# Altium schematic sheet LH-Cloud-STM32F407IGT6-V1.0.SchDoc(LH-Cloud-STM32F407IGT6-V1.0)
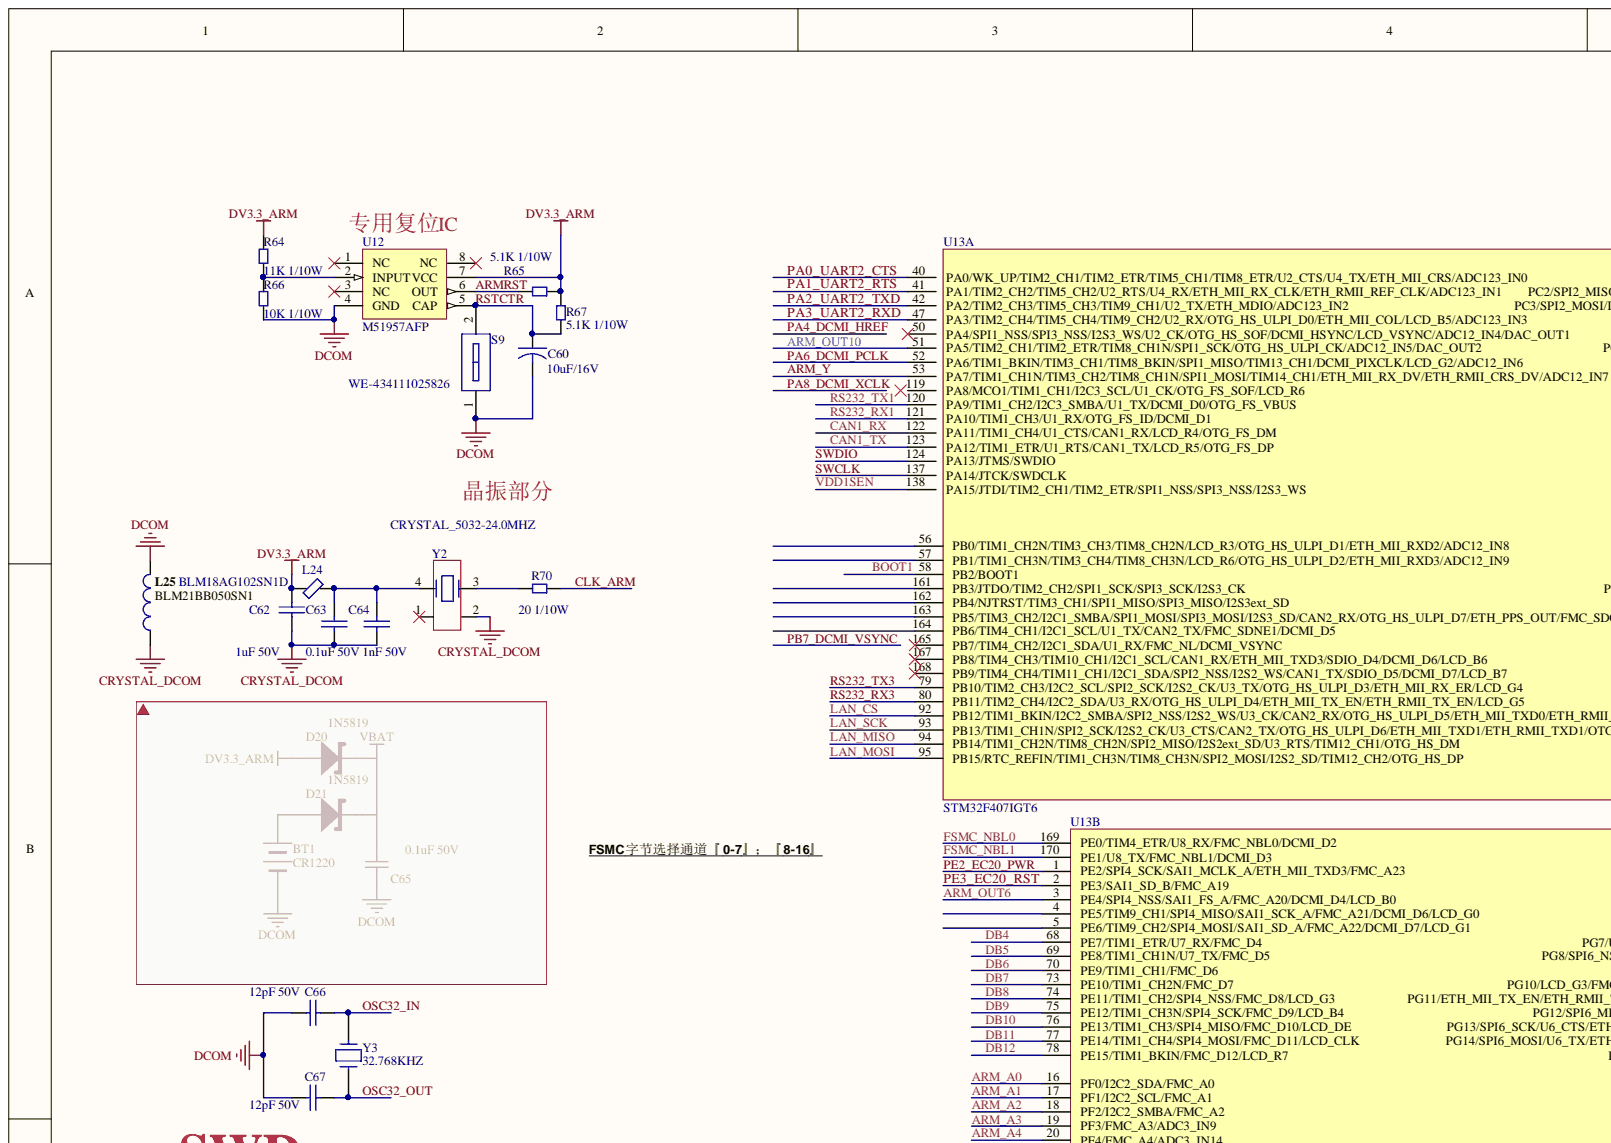
[diagram p0: LH-Cloud-STM32F407IGT6-V1.0.SchDoc, top left]
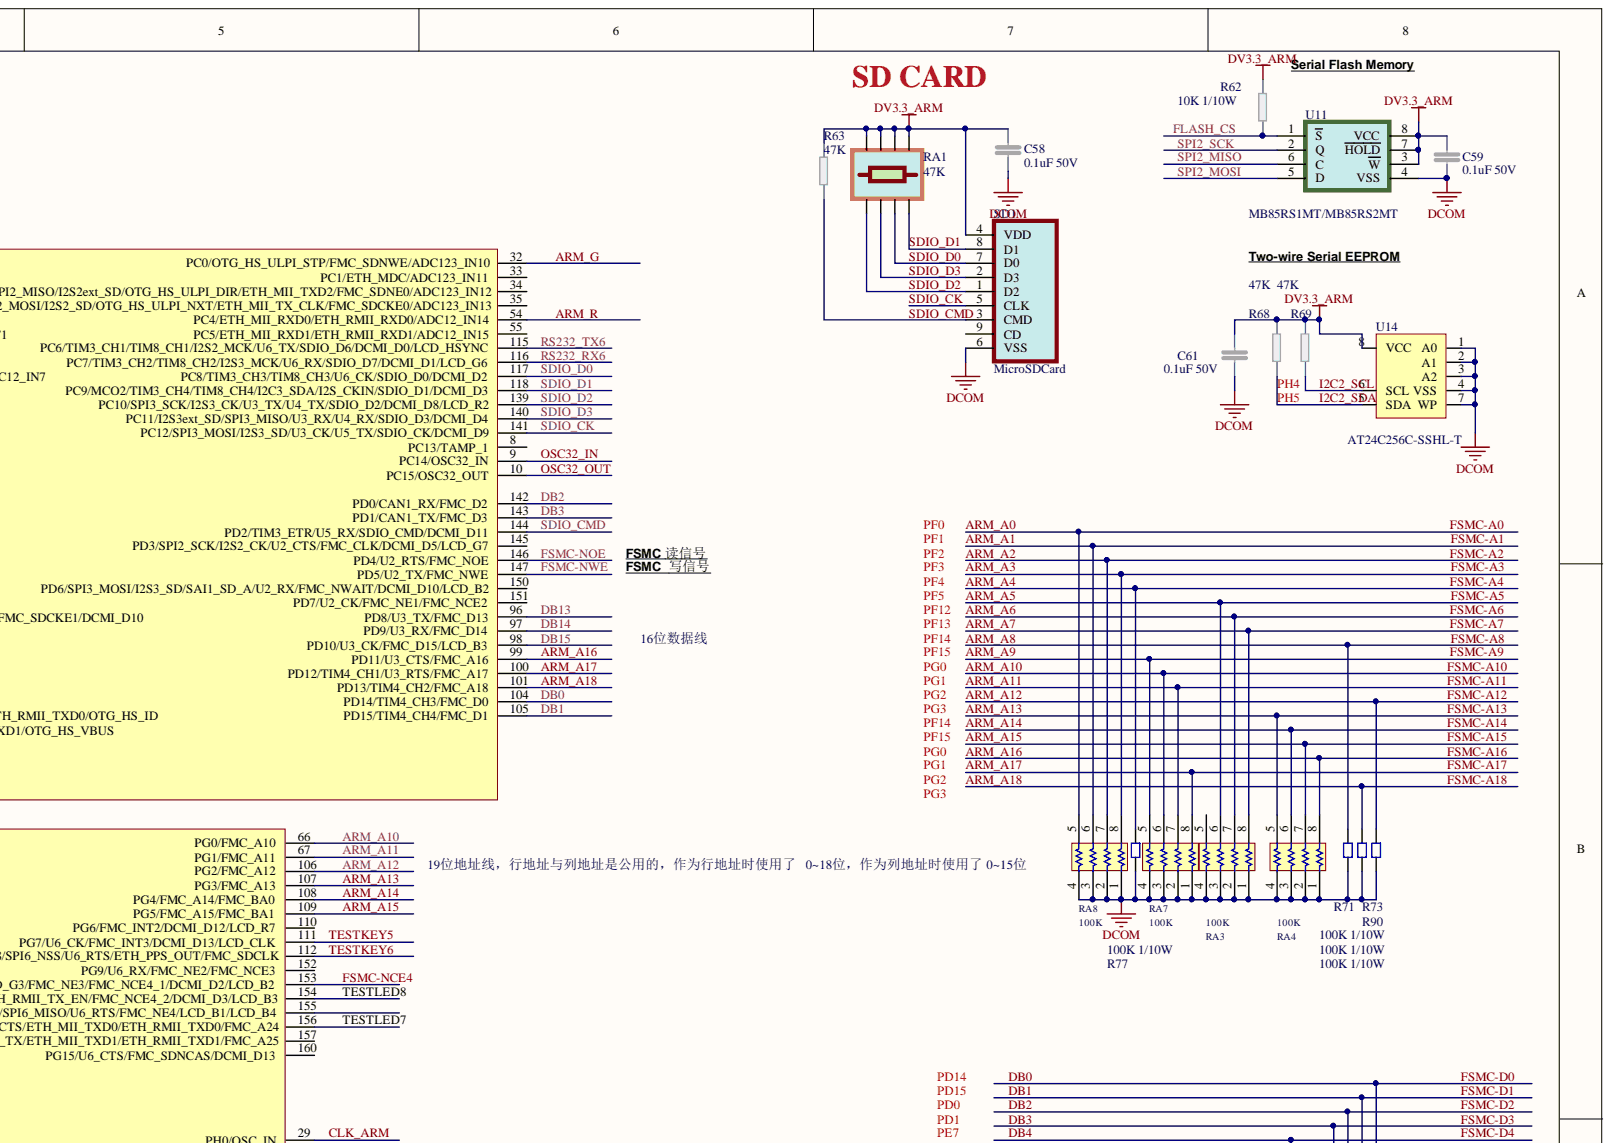
[diagram p1: LH-Cloud-STM32F407IGT6-V1.0.SchDoc, top right]
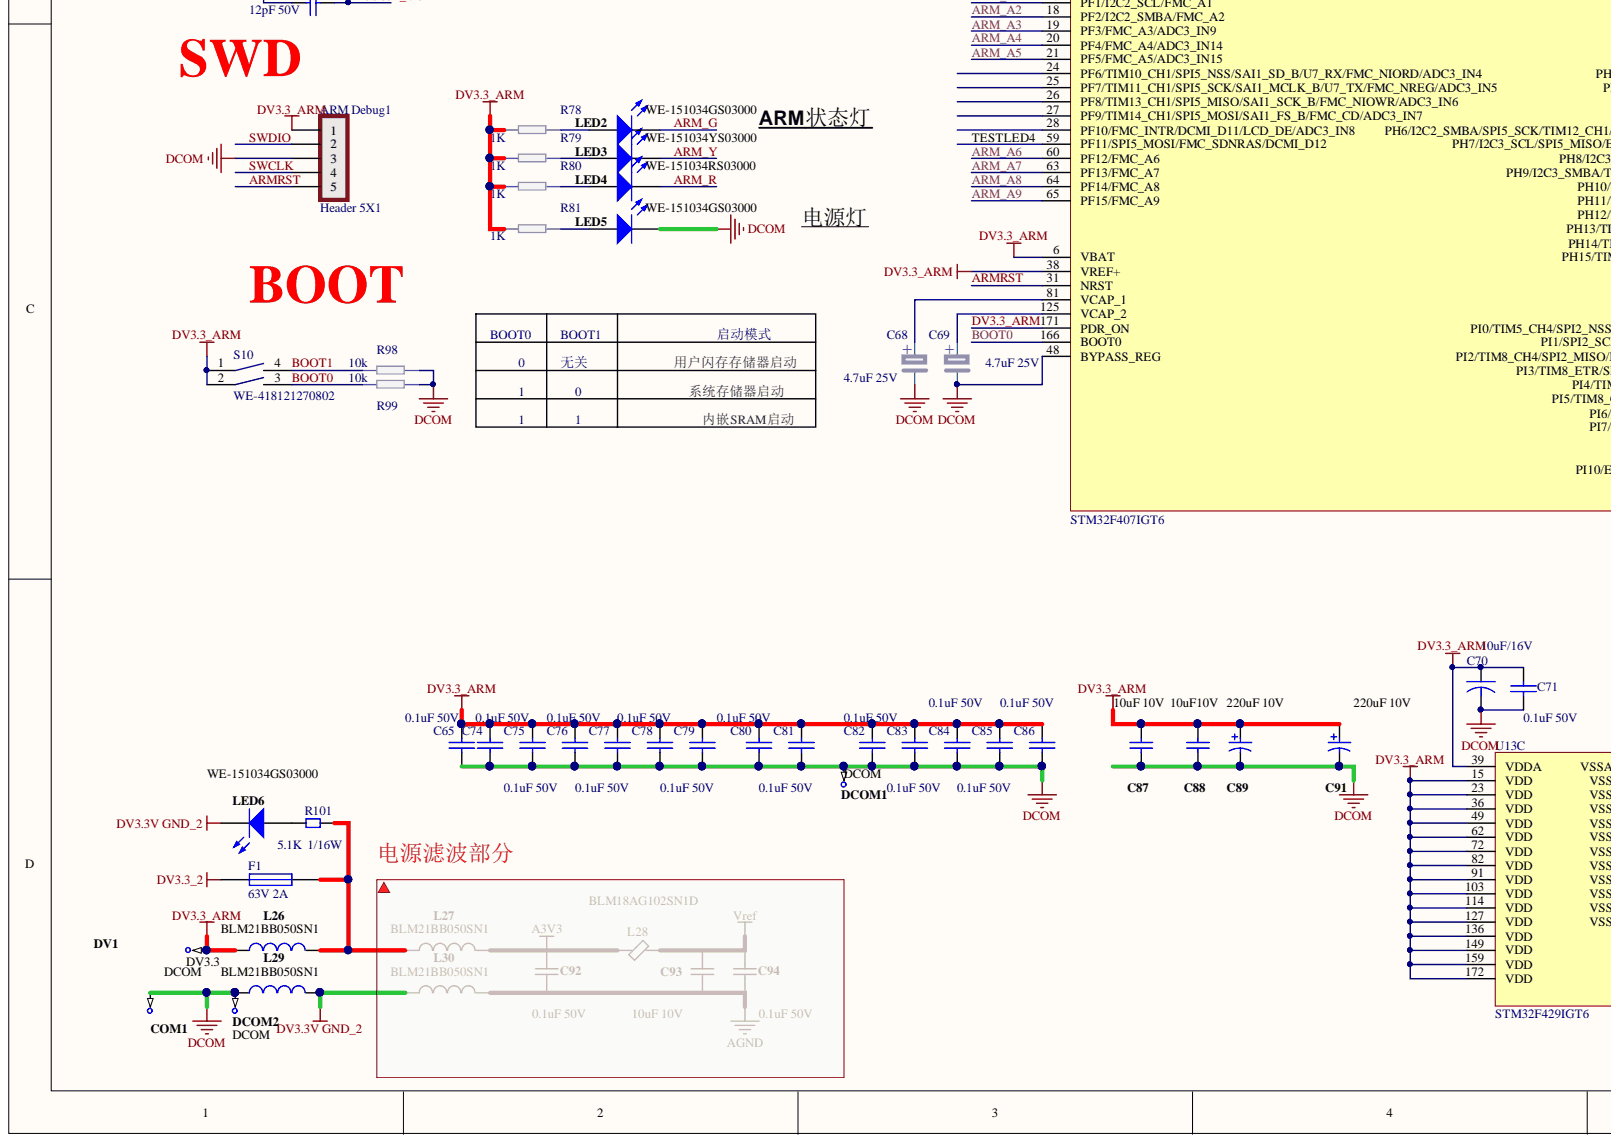
[diagram p2: LH-Cloud-STM32F407IGT6-V1.0.SchDoc, bottom left]
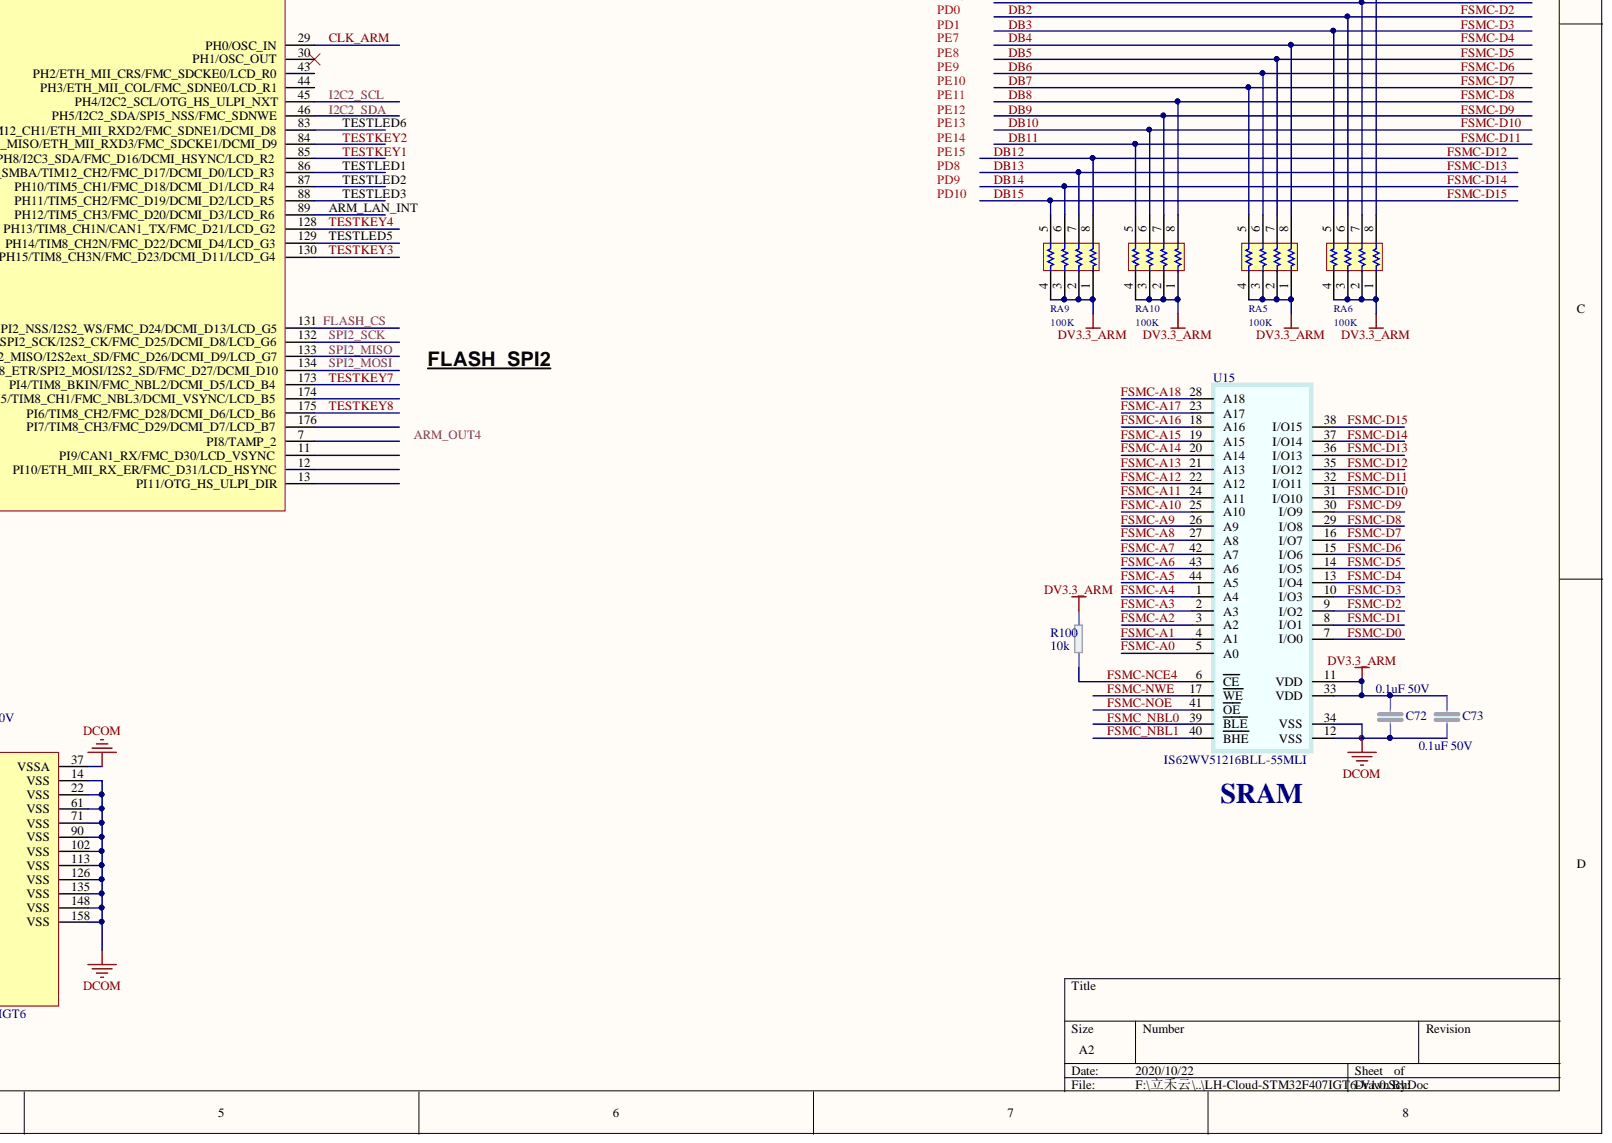
[diagram p3: LH-Cloud-STM32F407IGT6-V1.0.SchDoc, bottom right]

## Parts
ARM_Debug1  Header 5X1  [WR-WTB-2.5mm-5pin_68800511622]
C58  0.1uF 50V  [C0603]
C59  0.1uF 50V  [C0603]
C60  10uF/16V  [CAPBCASE]
C61  0.1uF 50V  [0603P]
C62  1uF 50V  [C0603_105/50V]
C63  0.1uF 50V  [C0603]
C64  1nF 50V  [C0603_102/50V]
C65  0.1uF 50V  value 0.1uF  [C0603]
C66  12pF 50V  [C0603_120/50V]
C67  12pF 50V  [C0603_120/50V]
C68  4.7uF 25V  [TAN-A]
C69  4.7uF 25V  [TAN-A]
C70  10uF/16V  [CAPBCASE_106/16V]
C71  0.1uF 50V  [C0603]
C72  0.1uF 50V  [C0603_104/50V]
C73  0.1uF 50V  [C0603_104/50V]
C74  0.1uF 50V  value 0.1uF  [C0603]
C75  0.1uF 50V  value 0.1uF  [C0603]
C76  0.1uF 50V  value 0.1uF  [C0603]
C77  0.1uF 50V  value 0.1uF  [C0603]
C78  0.1uF 50V  value 0.1uF  [C0603]
C79  0.1uF 50V  value 0.1uF  [C0603]
C80  0.1uF 50V  value 0.1uF  [C0603]
C81  0.1uF 50V  value 0.1uF  [C0603]
C82  0.1uF 50V  value 0.1uF  [C0603]
C83  0.1uF 50V  value 0.1uF  [C0603]
C84  0.1uF 50V  value 0.1uF  [C0603]
C85  0.1uF 50V  value 0.1uF  [C0603]
C86  0.1uF 50V  value 0.1uF  [C0603]
C87  10uF 10V  [C0805_106/50V]
C88  10uF10V  [C0805_106/50V]
C89  220uF 10V  [CAPDCASE_E]
C91  220uF 10V  [CAPDCASE_E]
COM1  DCOM  [TestPin]  (no BOM)
DCOM1  DCOM  [TestPin]  (no BOM)
DCOM2  DCOM  [TestPin]  (no BOM)
DV1  DV3.3  [TestPin]  (no BOM)
F1  63V 2A  [F1206_1A/105V]
L24  BLM18AG102SN1D  [0603_BLM18AG102SN1D]
L25  BLM21BB050SN1  [0805_BLM21BB050SN1]
L26  BLM21BB050SN1  [0805_BLM21BB050SN1]
L29  BLM21BB050SN1  [0805_BLM21BB050SN1]
LED2  WE-151034GS03000  [LED_3MM]
LED3  WE-151034YS03000  [LED_3MM]
LED4  WE-151034RS03000  [LED_3MM]
LED5  WE-151034GS03000  [LED_3MM]
LED6  WE-151034GS03000  [LED_3MM]
R62  10K 1/10W  [res0603_103]
R63  47K  [RES0603_473]
R64  11K 1/10W  [res0603_113]
R65  5.1K 1/10W  [res0603_512]
R66  10K 1/10W  [res0603_103]
R67  5.1K 1/10W  [res0603_512]
R68  47K  [RES0603_473]
R69  47K  [RES0603_473]
R70  20 1/10W  [res0603_200]
R71  100K 1/10W  [RES0603_100]
R73  100K 1/10W  [RES0603_100]
R77  100K 1/10W  [RES0603_100]
R78  1K  [RES0603_102]
R79  1K  [RES0603_102]
R80  1K  [RES0603_102]
R81  1K  [RES0603_102]
R90  100K 1/10W  [RES0603_100]
R98  10k  [RES0603_104]
R99  10k  [RES0603_104]
R100  10k  [res0603_103]
R101  5.1K  1/16W  [res0603_512]
RA1  47K  [ra_yc164_102]
RA3  100K  [ra_yc164_104]
RA4  100K  [ra_yc164_104]
RA5  100K  [ra_yc164_104]
RA6  100K  [ra_yc164_104]
RA7  100K  [ra_yc164_104]
RA8  100K  [ra_yc164_104]
RA9  100K  [ra_yc164_104]
RA10  100K  [ra_yc164_104]
S9  WE-434111025826  [KEY735SO]
S10  WE-418121270802  [WS-DISV-2.54MM-2PIN_418121270802]
SD1  MicroSDCard  [microsdcard]
U11  MB85RS1MT/MB85RS2MT  [MB85RS2MT_SOP8W]
U12  M51957AFP  [SO8]
U13  STM32F407IGT6  [LQFP-176_STM32F407IGT6-176]  (parts A,B,C)
U14  AT24C256C-SSHL-T  [SOIC8_AT24C08]
U15  IS62WV51216BLL-55MLI  [IS62WV51216BLL_TSOP44]
Y2  CRYSTAL_5032-24.0MHZ  [CR-5032_24MHZ]
Y3  32.768KHZ  [CR_3215_32768]

## Nets
CRYSTAL_DCOM: C62.2 C63.2 C64.2 L25.1 Y2.2
DCOM: ARM_Debug1.3 C58.1 C59.2 C60.2 C61.2 C65.2 C66.2 C67.2 C68.2 C69.2 C70.2 C71.1 C72.2 C73.2 C74.2 C75.2 C76.2 C77.2 C78.2 C79.2 C80.2 C81.2 C82.2 C83.2 C84.2 C85.2 C86.2 C87.2 C88.2 C89.2 C91.2 COM1.1 DCOM1.1 DCOM2.1 L25.2 L29.1 LED5.2 R66.1 R71.2 R73.2 R77.2 R90.2 R98.2 R99.2 RA3.1 RA3.2 RA3.3 RA3.4 RA4.1 RA4.2 RA4.3 RA4.4 RA7.1 RA7.2 RA7.3 RA7.4 RA8.1 RA8.2 RA8.3 RA8.4 S9.1 SD1.6(VSS) U11.4(VSS) U12.4(GND) U13.14(VSS) U13.22(VSS) U13.37(VSSA) U13.48(BYPASS_REG) U13.61(VSS) U13.71(VSS) U13.90(VSS) U13.102(VSS) U13.113(VSS) U13.126(VSS) U13.135(VSS) U13.148(VSS) U13.158(VSS) U14.1(A0) U14.2(A1) U14.3(A2) U14.4(VSS) U14.7(WP) U15.12(VSS) U15.34(VSS)
DV3.3_2: F1.2
DV3.3V GND_2: L29.2 LED6.2
ARM_A0: RA8.5 U13.16(PF0/I2C2_SDA/FMC_A0) U15.5(A0)
ARM_A1: RA8.6 U13.17(PF1/I2C2_SCL/FMC_A1) U15.4(A1)
ARM_A2: RA8.7 U13.18(PF2/I2C2_SMBA/FMC_A2) U15.3(A2)
ARM_A3: RA8.8 U13.19(PF3/FMC_A3/ADC3_IN9) U15.2(A3)
ARM_A4: R77.1 U13.20(PF4/FMC_A4/ADC3_IN14) U15.1(A4)
ARM_A5: RA3.6 U13.21(PF5/FMC_A5/ADC3_IN15) U15.44(A5)
ARM_A6: RA3.7 U13.60(PF12/FMC_A6) U15.43(A6)
ARM_A7: RA3.8 U13.63(PF13/FMC_A7) U15.42(A7)
ARM_A8: R71.1 U13.64(PF14/FMC_A8) U15.27(A8)
ARM_A9: RA7.5 U13.65(PF15/FMC_A9) U15.26(A9)
ARM_A10: RA7.6 U13.66(PG0/FMC_A10) U15.25(A10)
ARM_A11: RA7.7 U13.67(PG1/FMC_A11) U15.24(A11)
ARM_A12: R90.1 U13.106(PG2/FMC_A12) U15.22(A12)
ARM_A13: RA4.5 U13.107(PG3/FMC_A13) U15.21(A13)
ARM_A14: RA4.6 U13.108(PG4/FMC_A14/FMC_BA0) U15.20(A14)
ARM_A15: RA4.7 U13.109(PG5/FMC_A15/FMC_BA1) U15.19(A15)
ARM_A16: RA4.8 U13.99(PD11/U3_CTS/FMC_A16) U15.18(A16)
ARM_A17: RA7.8 U13.100(PD12/TIM4_CH1/U3_RTS/FMC_A17) U15.23(A17)
ARM_A18: R73.1 U13.101(PD13/TIM4_CH2/FMC_A18) U15.28(A18)
ARM_G: LED2.2 U13.32(PC0/OTG_HS_ULPI_STP/FMC_SDNWE/ADC123_IN10)
ARM_LAN_INT: U13.89(PH12/TIM5_CH3/FMC_D20/DCMI_D3/LCD_R6)
ARM_OUT6: U13.3(PE4/SPI4_NSS/SAI1_FS_A/FMC_A20/DCMI_D4/LCD_B0)
ARM_OUT10: U13.51(PA5/TIM2_CH1/TIM2_ETR/TIM8_CH1N/SPI1_SCK/OTG_HS_ULPI_CK/ADC12_IN5/DAC_OUT2)
ARM_R: LED4.2 U13.54(PC4/ETH_MII_RXD0/ETH_RMII_RXD0/ADC12_IN14)
ARM_Y: LED3.2 U13.53(PA7/TIM1_CH1N/TIM3_CH2/TIM8_CH1N/SPI1_MOSI/TIM14_CH1/ETH_MII_RX_DV/ETH_RMII_CRS_DV/ADC12_IN7)
ARMRST: ARM_Debug1.5 R65.2 U12.6(OUT) U13.31(NRST)
BOOT0: R99.1 S10.3 U13.166(BOOT0)
BOOT1: R98.1 S10.4 U13.58(PB2/BOOT1)
CAN1_RX: U13.122(PA11/TIM1_CH4/U1_CTS/CAN1_RX/LCD_R4/OTG_FS_DM)
CAN1_TX: U13.123(PA12/TIM1_ETR/U1_RTS/CAN1_TX/LCD_R5/OTG_FS_DP)
CLK_ARM: R70.1 U13.29(PH0/OSC_IN)
DB0: RA6.8 U13.104(PD14/TIM4_CH3/FMC_D0) U15.7(I/O0)
DB1: RA6.7 U13.105(PD15/TIM4_CH4/FMC_D1) U15.8(I/O1)
DB2: RA6.6 U13.142(PD0/CAN1_RX/FMC_D2) U15.9(I/O2)
DB3: RA6.5 U13.143(PD1/CAN1_TX/FMC_D3) U15.10(I/O3)
DB4: RA5.8 U13.68(PE7/TIM1_ETR/U7_RX/FMC_D4) U15.13(I/O4)
DB5: RA5.7 U13.69(PE8/TIM1_CH1N/U7_TX/FMC_D5) U15.14(I/O5)
DB6: RA5.6 U13.70(PE9/TIM1_CH1/FMC_D6) U15.15(I/O6)
DB7: RA5.5 U13.73(PE10/TIM1_CH2N/FMC_D7) U15.16(I/O7)
DB8: RA10.8 U13.74(PE11/TIM1_CH2/SPI4_NSS/FMC_D8/LCD_G3) U15.29(I/O8)
DB9: RA10.7 U13.75(PE12/TIM1_CH3N/SPI4_SCK/FMC_D9/LCD_B4) U15.30(I/O9)
DB10: RA10.6 U13.76(PE13/TIM1_CH3/SPI4_MISO/FMC_D10/LCD_DE) U15.31(I/O10)
DB11: RA10.5 U13.77(PE14/TIM1_CH4/SPI4_MOSI/FMC_D11/LCD_CLK) U15.32(I/O11)
DB12: RA9.8 U13.78(PE15/TIM1_BKIN/FMC_D12/LCD_R7) U15.35(I/O12)
DB13: RA9.7 U13.96(PD8/U3_TX/FMC_D13) U15.36(I/O13)
DB14: RA9.6 U13.97(PD9/U3_RX/FMC_D14) U15.37(I/O14)
DB15: RA9.5 U13.98(PD10/U3_CK/FMC_D15/LCD_B3) U15.38(I/O15)
DV3.3_ARM: ARM_Debug1.1 C58.2 C59.1 C61.1 C62.1 C65.1 C70.1 C71.2 C72.1 C73.1 C74.1 C75.1 C76.1 C77.1 C78.1 C79.1 C80.1 C81.1 C82.1 C83.1 C84.1 C85.1 C86.1 C87.1 C88.1 C89.1 C91.1 DV1.1 L24.1 L26.1 R62.2 R63.2 R64.2 R65.1 R67.1 R68.2 R69.2 R78.1 R79.1 R80.1 R81.1 R100.2 RA1.5 RA1.6 RA1.7 RA1.8 RA5.1 RA5.2 RA5.3 RA5.4 RA6.1 RA6.2 RA6.3 RA6.4 RA9.1 RA9.2 RA9.3 RA9.4 RA10.1 RA10.2 RA10.3 RA10.4 S10.1 S10.2 SD1.4(VDD) U11.3(W) U11.7(HOLD) U11.8(VCC) U12.7(VCC) U13.6(VBAT) U13.15(VDD) U13.23(VDD) U13.36(VDD) U13.38(VREF+) U13.39(VDDA) U13.49(VDD) U13.62(VDD) U13.72(VDD) U13.82(VDD) U13.91(VDD) U13.103(VDD) U13.114(VDD) U13.127(VDD) U13.136(VDD) U13.149(VDD) U13.159(VDD) U13.171(PDR_ON) U13.172(VDD) U14.8(VCC) U15.11(VDD) U15.33(VDD)
FLASH_CS: R62.1 U11.1(S) U13.131(PI0/TIM5_CH4/SPI2_NSS/I2S2_WS/FMC_D24/DCMI_D13/LCD_G5)
FSMC-NCE4: R100.1 U13.153(PG10/LCD_G3/FMC_NE3/FMC_NCE4_1/DCMI_D2/LCD_B2) U15.6(CE)
FSMC-NOE: U13.146(PD4/U2_RTS/FMC_NOE) U15.41(OE)
FSMC-NWE: U13.147(PD5/U2_TX/FMC_NWE) U15.17(WE)
FSMC_NBL0: U13.169(PE0/TIM4_ETR/U8_RX/FMC_NBL0/DCMI_D2) U15.39(BLE)
FSMC_NBL1: U13.170(PE1/U8_TX/FMC_NBL1/DCMI_D3) U15.40(BHE)
I2C2_SCL: R69.1 U13.45(PH4/I2C2_SCL/OTG_HS_ULPI_NXT) U14.6(SCL)
I2C2_SDA: R68.1 U13.46(PH5/I2C2_SDA/SPI5_NSS/FMC_SDNWE) U14.5(SDA)
LAN_CS: U13.92(PB12/TIM1_BKIN/I2C2_SMBA/SPI2_NSS/I2S2_WS/U3_CK/CAN2_RX/OTG_HS_ULPI_D5/ETH_MII_TXD0/ETH_RMII_TXD0/OTG_HS_ID)
LAN_MISO: U13.94(PB14/TIM1_CH2N/TIM8_CH2N/SPI2_MISO/I2S2ext_SD/U3_RTS/TIM12_CH1/OTG_HS_DM)
LAN_MOSI: U13.95(PB15/RTC_REFIN/TIM1_CH3N/TIM8_CH3N/SPI2_MOSI/I2S2_SD/TIM12_CH2/OTG_HS_DP)
LAN_SCK: U13.93(PB13/TIM1_CH1N/SPI2_SCK/I2S2_CK/U3_CTS/CAN2_TX/OTG_HS_ULPI_D6/ETH_MII_TXD1/ETH_RMII_TXD1/OTG_HS_VBUS)
OSC32_IN: C66.1 U13.9(PC14/OSC32_IN) Y3.2
OSC32_OUT: C67.1 U13.10(PC15/OSC32_OUT) Y3.1
PA0_UART2_CTS: U13.40(PA0/WK_UP/TIM2_CH1/TIM2_ETR/TIM5_CH1/TIM8_ETR/U2_CTS/U4_TX/ETH_MII_CRS/ADC123_IN0)
PA1_UART2_RTS: U13.41(PA1/TIM2_CH2/TIM5_CH2/U2_RTS/U4_RX/ETH_MII_RX_CLK/ETH_RMII_REF_CLK/ADC123_IN1)
PA2_UART2_TXD: U13.42(PA2/TIM2_CH3/TIM5_CH3/TIM9_CH1/U2_TX/ETH_MDIO/ADC123_IN2)
PA3_UART2_RXD: U13.47(PA3/TIM2_CH4/TIM5_CH4/TIM9_CH2/U2_RX/OTG_HS_ULPI_D0/ETH_MII_COL/LCD_B5/ADC123_IN3)
PA6_DCMI_PCLK: U13.52(PA6/TIM1_BKIN/TIM3_CH1/TIM8_BKIN/SPI1_MISO/TIM13_CH1/DCMI_PIXCLK/LCD_G2/ADC12_IN6)
PE2_EC20_PWR: U13.1(PE2/SPI4_SCK/SAI1_MCLK_A/ETH_MII_TXD3/FMC_A23)
PE3_EC20_RST: U13.2(PE3/SAI1_SD_B/FMC_A19)
RS232_RX1: U13.121(PA10/TIM1_CH3/U1_RX/OTG_FS_ID/DCMI_D1)
RS232_RX3: U13.80(PB11/TIM2_CH4/I2C2_SDA/U3_RX/OTG_HS_ULPI_D4/ETH_MII_TX_EN/ETH_RMII_TX_EN/LCD_G5)
RS232_RX6: U13.116(PC7/TIM3_CH2/TIM8_CH2/I2S3_MCK/U6_RX/SDIO_D7/DCMI_D1/LCD_G6)
RS232_TX1: U13.120(PA9/TIM1_CH2/I2C3_SMBA/U1_TX/DCMI_D0/OTG_FS_VBUS)
RS232_TX3: U13.79(PB10/TIM2_CH3/I2C2_SCL/SPI2_SCK/I2S2_CK/U3_TX/OTG_HS_ULPI_D3/ETH_MII_RX_ER/LCD_G4)
RS232_TX6: U13.115(PC6/TIM3_CH1/TIM8_CH1/I2S2_MCK/U6_TX/SDIO_D6/DCMI_D0/LCD_HSYNC)
RSTCTR: C60.1 R67.2 S9.2 U12.5(CAP)
SDIO_CK: SD1.5(CLK) U13.141(PC12/SPI3_MOSI/I2S3_SD/U3_CK/U5_TX/SDIO_CK/DCMI_D9)
SDIO_CMD: R63.1 SD1.3(CMD) U13.144(PD2/TIM3_ETR/U5_RX/SDIO_CMD/DCMI_D11)
SDIO_D0: RA1.2 SD1.7(D0) U13.117(PC8/TIM3_CH3/TIM8_CH3/U6_CK/SDIO_D0/DCMI_D2)
SDIO_D1: RA1.1 SD1.8(D1) U13.118(PC9/MCO2/TIM3_CH4/TIM8_CH4/I2C3_SDA/I2S_CKIN/SDIO_D1/DCMI_D3)
SDIO_D2: RA1.4 SD1.1(D2) U13.139(PC10/SPI3_SCK/I2S3_CK/U3_TX/U4_TX/SDIO_D2/DCMI_D8/LCD_R2)
SDIO_D3: RA1.3 SD1.2(D3) U13.140(PC11/I2S3ext_SD/SPI3_MISO/U3_RX/U4_RX/SDIO_D3/DCMI_D4)
SPI2_MISO: U11.6(C) U13.133(PI2/TIM8_CH4/SPI2_MISO/I2S2ext_SD/FMC_D26/DCMI_D9/LCD_G7)
SPI2_MOSI: U11.5(D) U13.134(PI3/TIM8_ETR/SPI2_MOSI/I2S2_SD/FMC_D27/DCMI_D10)
SPI2_SCK: U11.2(Q) U13.132(PI1/SPI2_SCK/I2S2_CK/FMC_D25/DCMI_D8/LCD_G6)
SWCLK: ARM_Debug1.4 U13.137(PA14/JTCK/SWDCLK)
SWDIO: ARM_Debug1.2 U13.124(PA13/JTMS/SWDIO)
TESTKEY1: U13.85(PH8/I2C3_SDA/FMC_D16/DCMI_HSYNC/LCD_R2)
TESTKEY2: U13.84(PH7/I2C3_SCL/SPI5_MISO/ETH_MII_RXD3/FMC_SDCKE1/DCMI_D9)
TESTKEY3: U13.130(PH15/TIM8_CH3N/FMC_D23/DCMI_D11/LCD_G4)
TESTKEY4: U13.128(PH13/TIM8_CH1N/CAN1_TX/FMC_D21/LCD_G2)
TESTKEY5: U13.111(PG7/U6_CK/FMC_INT3/DCMI_D13/LCD_CLK)
TESTKEY6: U13.112(PG8/SPI6_NSS/U6_RTS/ETH_PPS_OUT/FMC_SDCLK)
TESTKEY7: U13.173(PI4/TIM8_BKIN/FMC_NBL2/DCMI_D5/LCD_B4)
TESTKEY8: U13.175(PI6/TIM8_CH2/FMC_D28/DCMI_D6/LCD_B6)
TESTLED1: U13.86(PH9/I2C3_SMBA/TIM12_CH2/FMC_D17/DCMI_D0/LCD_R3)
TESTLED2: U13.87(PH10/TIM5_CH1/FMC_D18/DCMI_D1/LCD_R4)
TESTLED3: U13.88(PH11/TIM5_CH2/FMC_D19/DCMI_D2/LCD_R5)
TESTLED4: U13.59(PF11/SPI5_MOSI/FMC_SDNRAS/DCMI_D12)
TESTLED5: U13.129(PH14/TIM8_CH2N/FMC_D22/DCMI_D4/LCD_G3)
TESTLED6: U13.83(PH6/I2C2_SMBA/SPI5_SCK/TIM12_CH1/ETH_MII_RXD2/FMC_SDNE1/DCMI_D8)
TESTLED7: U13.156(PG13/SPI6_SCK/U6_CTS/ETH_MII_TXD0/ETH_RMII_TXD0/FMC_A24)
TESTLED8: U13.154(PG11/ETH_MII_TX_EN/ETH_RMII_TX_EN/FMC_NCE4_2/DCMI_D3/LCD_B3)
VDD1SEN: U13.138(PA15/JTDI/TIM2_CH1/TIM2_ETR/SPI1_NSS/SPI3_NSS/I2S3_WS)
NetC63_1: C63.1 C64.1 L24.2 Y2.4
NetC68_1: C68.1 U13.81(VCAP_1)
NetC69_1: C69.1 U13.125(VCAP_2)
NetF1_1: F1.1 L26.2 R101.1
NetLED2_1: LED2.1 R78.2
NetLED3_1: LED3.1 R79.2
NetLED4_1: LED4.1 R80.2
NetLED5_1: LED5.1 R81.2
NetLED6_1: LED6.1 R101.2
NetR64_1: R64.1 R66.2 U12.2(INPUT)
NetR70_2: R70.2 Y2.3
ARM_OUT4:
PA4_DCMI_HREF:
PA8_DCMI_XCLK:
PB7_DCMI_VSYNC:

Not connected: RA3 5; SD1 9(CD); U12 1(NC) 3(NC) 8(NC); U13 4(PE5/TIM9_CH1/SPI4_MISO/SAI1_SCK_A/FMC_A21/DCMI_D6/LCD_G0) 5(PE6/TIM9_CH2/SPI4_MOSI/SAI1_SD_A/FMC_A22/DCMI_D7/LCD_G1) 7(PI8/TAMP_2) 8(PC13/TAMP_1) 11(PI9/CAN1_RX/FMC_D30/LCD_VSYNC) 12(PI10/ETH_MII_RX_ER/FMC_D31/LCD_HSYNC) 13(PI11/OTG_HS_ULPI_DIR) 24(PF6/TIM10_CH1/SPI5_NSS/SAI1_SD_B/U7_RX/FMC_NIORD/ADC3_IN4) 25(PF7/TIM11_CH1/SPI5_SCK/SAI1_MCLK_B/U7_TX/FMC_NREG/ADC3_IN5) 26(PF8/TIM13_CH1/SPI5_MISO/SAI1_SCK_B/FMC_NIOWR/ADC3_IN6) 27(PF9/TIM14_CH1/SPI5_MOSI/SAI1_FS_B/FMC_CD/ADC3_IN7) 28(PF10/FMC_INTR/DCMI_D11/LCD_DE/ADC3_IN8) 30(PH1/OSC_OUT) 33(PC1/ETH_MDC/ADC123_IN11) 34(PC2/SPI2_MISO/I2S2ext_SD/OTG_HS_ULPI_DIR/ETH_MII_TXD2/FMC_SDNE0/ADC123_IN12) 35(PC3/SPI2_MOSI/I2S2_SD/OTG_HS_ULPI_NXT/ETH_MII_TX_CLK/FMC_SDCKE0/ADC123_IN13) 43(PH2/ETH_MII_CRS/FMC_SDCKE0/LCD_R0) 44(PH3/ETH_MII_COL/FMC_SDNE0/LCD_R1) 50(PA4/SPI1_NSS/SPI3_NSS/I2S3_WS/U2_CK/OTG_HS_SOF/DCMI_HSYNC/LCD_VSYNC/ADC12_IN4/DAC_OUT1) 55(PC5/ETH_MII_RXD1/ETH_RMII_RXD1/ADC12_IN15) 56(PB0/TIM1_CH2N/TIM3_CH3/TIM8_CH2N/LCD_R3/OTG_HS_ULPI_D1/ETH_MII_RXD2/ADC12_IN8) 57(PB1/TIM1_CH3N/TIM3_CH4/TIM8_CH3N/LCD_R6/OTG_HS_ULPI_D2/ETH_MII_RXD3/ADC12_IN9) 110(PG6/FMC_INT2/DCMI_D12/LCD_R7) 119(PA8/MCO1/TIM1_CH1/I2C3_SCL/U1_CK/OTG_FS_SOF/LCD_R6) 145(PD3/SPI2_SCK/I2S2_CK/U2_CTS/FMC_CLK/DCMI_D5/LCD_G7) 150(PD6/SPI3_MOSI/I2S3_SD/SAI1_SD_A/U2_RX/FMC_NWAIT/DCMI_D10/LCD_B2) 151(PD7/U2_CK/FMC_NE1/FMC_NCE2) 152(PG9/U6_RX/FMC_NE2/FMC_NCE3) 155(PG12/SPI6_MISO/U6_RTS/FMC_NE4/LCD_B1/LCD_B4) 157(PG14/SPI6_MOSI/U6_TX/ETH_MII_TXD1/ETH_RMII_TXD1/FMC_A25) 160(PG15/U6_CTS/FMC_SDNCAS/DCMI_D13) 161(PB3/JTDO/TIM2_CH2/SPI1_SCK/SPI3_SCK/I2S3_CK) 162(PB4/NJTRST/TIM3_CH1/SPI1_MISO/SPI3_MISO/I2S3ext_SD) 163(PB5/TIM3_CH2/I2C1_SMBA/SPI1_MOSI/SPI3_MOSI/I2S3_SD/CAN2_RX/OTG_HS_ULPI_D7/ETH_PPS_OUT/FMC_SDCKE1/DCMI_D10) 164(PB6/TIM4_CH1/I2C1_SCL/U1_TX/CAN2_TX/FMC_SDNE1/DCMI_D5) 165(PB7/TIM4_CH2/I2C1_SDA/U1_RX/FMC_NL/DCMI_VSYNC) 167(PB8/TIM4_CH3/TIM10_CH1/I2C1_SCL/CAN1_RX/ETH_MII_TXD3/SDIO_D4/DCMI_D6/LCD_B6) 168(PB9/TIM4_CH4/TIM11_CH1/I2C1_SDA/SPI2_NSS/I2S2_WS/CAN1_TX/SDIO_D5/DCMI_D7/LCD_B7) 174(PI5/TIM8_CH1/FMC_NBL3/DCMI_VSYNC/LCD_B5) 176(PI7/TIM8_CH3/FMC_D29/DCMI_D7/LCD_B7); Y2 1

## Library data of the parts
ARM_Debug1 = HDR5X1: Library Name: 2017.4.24 MainBoard.SchLib; Description: Header 5X1; Component Kind: Standard
C58 C59 C61 = CAP: Capacitor, Ceramic, 0.1uF 10% 16V 0603  X7R; Library Name: 3DGeneral.SCHLIB; Component Kind: Standard
C60 = CAPACITOR: AVX  TAN Capacitor; Component Kind: Standard
C62-C64 C72 C73 = CAP: 'SAMSUNG  MULTILAYER  CERAMIC 5%  0603 X7R; Component Kind: Standard
  C72 C73: Library Name: 3DGeneral.SCHLIB
C65 C74-C86 = CAP: Capacitor, Ceramic, 0.1uF 10% 50V 0603; MFG Part Number: C0603C104K5RACTU; Manufacturer: Kemet; Component Kind: Standard
C66 C67 = CAP: Capacitor, Ceramic, 10nF 10% 16V 0603 X7R; Component Kind: Standard
C68 C69 = CAPACITOR: Library Name: 3DGeneral.SCHLIB; Description: Capacitor; Component Kind: Standard
C70 = CAPACITOR: AVX TAN B CASE; Component Kind: Standard
C71 = CAP: Capacitor; Component Kind: Standard
C87 C88 = D-101-0087: Capacitor, Ceramic, 10uF 10% 10V 0805 (2012) X5R; Polarity: Non-Polarized; Tolerance: 10 %; Technology: None; Status: Unreleased; Rated Voltage: 10 V; Mounting Technology: Surface Mount; Library Name: AltiumDesignLibrary.DesignLib; Dielectric: X5R; Component Kind: Standard; Category: Capacitor; Capacitance: 10 uF
C89 C91 = D-101-0088: AVX Capacitor, Tantalum, 220uF 10% 10V MCCT-D (7343-31); Polarity: Polarized; Tolerance: 10 %; Technology: Tantalum; Status: Unreleased; Rated Voltage: 10 V; PCB3D: GCap; Mounting Technology: Surface Mount; Library Name: AltiumDesignLibrary.DesignLib; Component Kind: Standard; Category: Capacitor; Capacitance: 220 uF
COM1 DCOM1 DCOM2 DV1 = D-006-0000: Test Point, PCB Mount with Silkscreen Box; Status: Production; Library Name: AltiumDesignLibrary.DesignLib; Category: Test-Point
F1 = FUSE1: Littelfuse Surface Mount Fuses 501Series-Hight Current 1206 Fast-ACT; Component Kind: Standard
L24 = FERRITE BEAD: EMIFIL (Inductor type) Chip Ferrite Bead BLM18A Series (0603 Size); Component Kind: Standard
L25 L26 L29 = D-102-0002: Inductor, Ferrite Bead 1K@100MHz 300mA 0805 (2012) BLM21BB050SN1; Inductance: nH; Status: Production; Mounting Technology: Surface Mount; Max Input Current: 300 mA; Library Name: AltiumDesignLibrary.DesignLib; Component Kind: Standard; Category: Inductor
LED2 = D-153-0004: WL-TMRW LED, Green 3mm Waterclear without stopper; Peak Wave Length: 565 nm; Brightness: 12.5 mcd; Status: Production; Mounting Technology: Surface Mount; Library Name: AltiumDesignLibrary.DesignLib; Component Kind: Standard; Color: N/A; Category: LED
LED3 = D-153-0004: WL-TMRW LED, YELLOW 3mm Waterclear without stopper; Peak Wave Length: 565 nm; Brightness: 12.5 mcd; Status: Production; Mounting Technology: Surface Mount; Library Name: AltiumDesignLibrary.DesignLib; Component Kind: Standard; Color: N/A; Category: LED
LED4 = D-153-0004: WL-TMRW LED, RED 3mm Waterclear without stopper; Peak Wave Length: 565 nm; Brightness: 12.5 mcd; Status: Production; Mounting Technology: Surface Mount; Library Name: AltiumDesignLibrary.DesignLib; Component Kind: Standard; Color: N/A; Category: LED
LED5 LED6 = D-153-0003: WL-TMRW LED, Green 3mm Waterclear without stopper; Peak Wave Length: 639 nm; Brightness: 17.0 mcd; Status: Production; Mounting Technology: Surface Mount; Library Name: AltiumDesignLibrary.DesignLib; Component Kind: Standard; Color: N/A; Category: LED
R62 R63 R78-R81 R100 = Res2: YAGEO Chip Res 1/10W 1%; Simulation: RESISTOR; Signal Integrity: Res; Published: 8-Jun-2000; PackageReference: AXIAL-0.4; Library Name: 3DGeneral.SCHLIB; LatestRevisionNote: Re-released for DXP Platform.; LatestRevisionDate: 17-Jul-2002; Component Kind: Standard; Publisher: Altium Limited
R64-R67 R70 = RES2: YAGEO Chip Res 1/10W 1%; Component Kind: Standard
R68 R69 = Res2: Resistor; Simulation: RESISTOR; Signal Integrity: Res; Published: 8-Jun-2000; PackageReference: AXIAL-0.4; Library Name: 3DGeneral.SCHLIB; LatestRevisionNote: Re-released for DXP Platform.; LatestRevisionDate: 17-Jul-2002; Component Kind: Standard; Publisher: Altium Limited
R71 R73 R77 R90 R101 = RES2: Component Kind: Standard
R98 R99 = Res2: YAGEO Chip Res 1/16W 1%; Simulation: RESISTOR; Signal Integrity: Res; Published: 8-Jun-2000; PackageReference: AXIAL-0.4; Library Name: 3DGeneral.SCHLIB; LatestRevisionNote: Re-released for DXP Platform.; LatestRevisionDate: 17-Jul-2002; Component Kind: Standard; Publisher: Altium Limited
RA1 = RA: YAGEO  5%   1608x4  Resistor Array; Library Name: 3DGeneral.SCHLIB; Component Kind: Standard
RA3-RA10 = MNR34: YAGEO  5%   1608x4  Resistor Array; AEMS Code: 1300330; Library Name: 2015.10.1.SCHLIB; Component Kind: Standard
S9 = SW DIP-2: WS-TASV SMD Tact Switch; Library Name: 2017.4.24 MainBoard.SchLib; Component Kind: Standard
S10 = BMX2: Small Compact SMD Dip Swith; Library Name: 3DGeneral.SCHLIB; Component Kind: Standard
SD1 = SDCARD: Library Name: 3DGeneral.SCHLIB; Description: MicroSDCard; Component Kind: Standard
U11 = M25P32: 512 Kbit to 32 Mbit, Low Voltage, Serial Flash Memory With 40 MHz or 50 MHz SPI Bus Interface; Library Name: 3DGeneral.SCHLIB; Component Kind: Standard
U12 = M51957: VOLTAGE DETECTING, SYSTEM RESETTING IC SERIES; Library Name: 2015.10.1.SCHLIB; Component Kind: Standard
U13 = STM32F429IGT6: ARM Cortex-M4 32b MCU+FPU, 210DMIPS, up to 1MB Flash/192+4KB RAM; Library Name: 2015.10.1.SCHLIB; Component Kind: Standard
U14 = 24CXX: Two-wire Serial EEPROM; Library Name: Core-Board.SCHLIB; Component Kind: Standard
U15 = IS64WV51216BLL-10MA3: 512K x 16 LOW VOLTAGE, ULTRA LOW POWER CMOS STATIC RAM; Library Name: 3DGeneral.SCHLIB; Component Kind: Standard
Y2 = CRYSTAL_5032: SG5032CAN24.0MHZTJGA; Library Name: 2017.4.24 MainBoard.SchLib; Component Kind: Standard
Y3 = CRYSTAL: Library Name: 2015.10.1.SCHLIB; Description: Crystal; Component Kind: Standard
